# Altium schematic sheet [10]Giroscopio.SchDoc
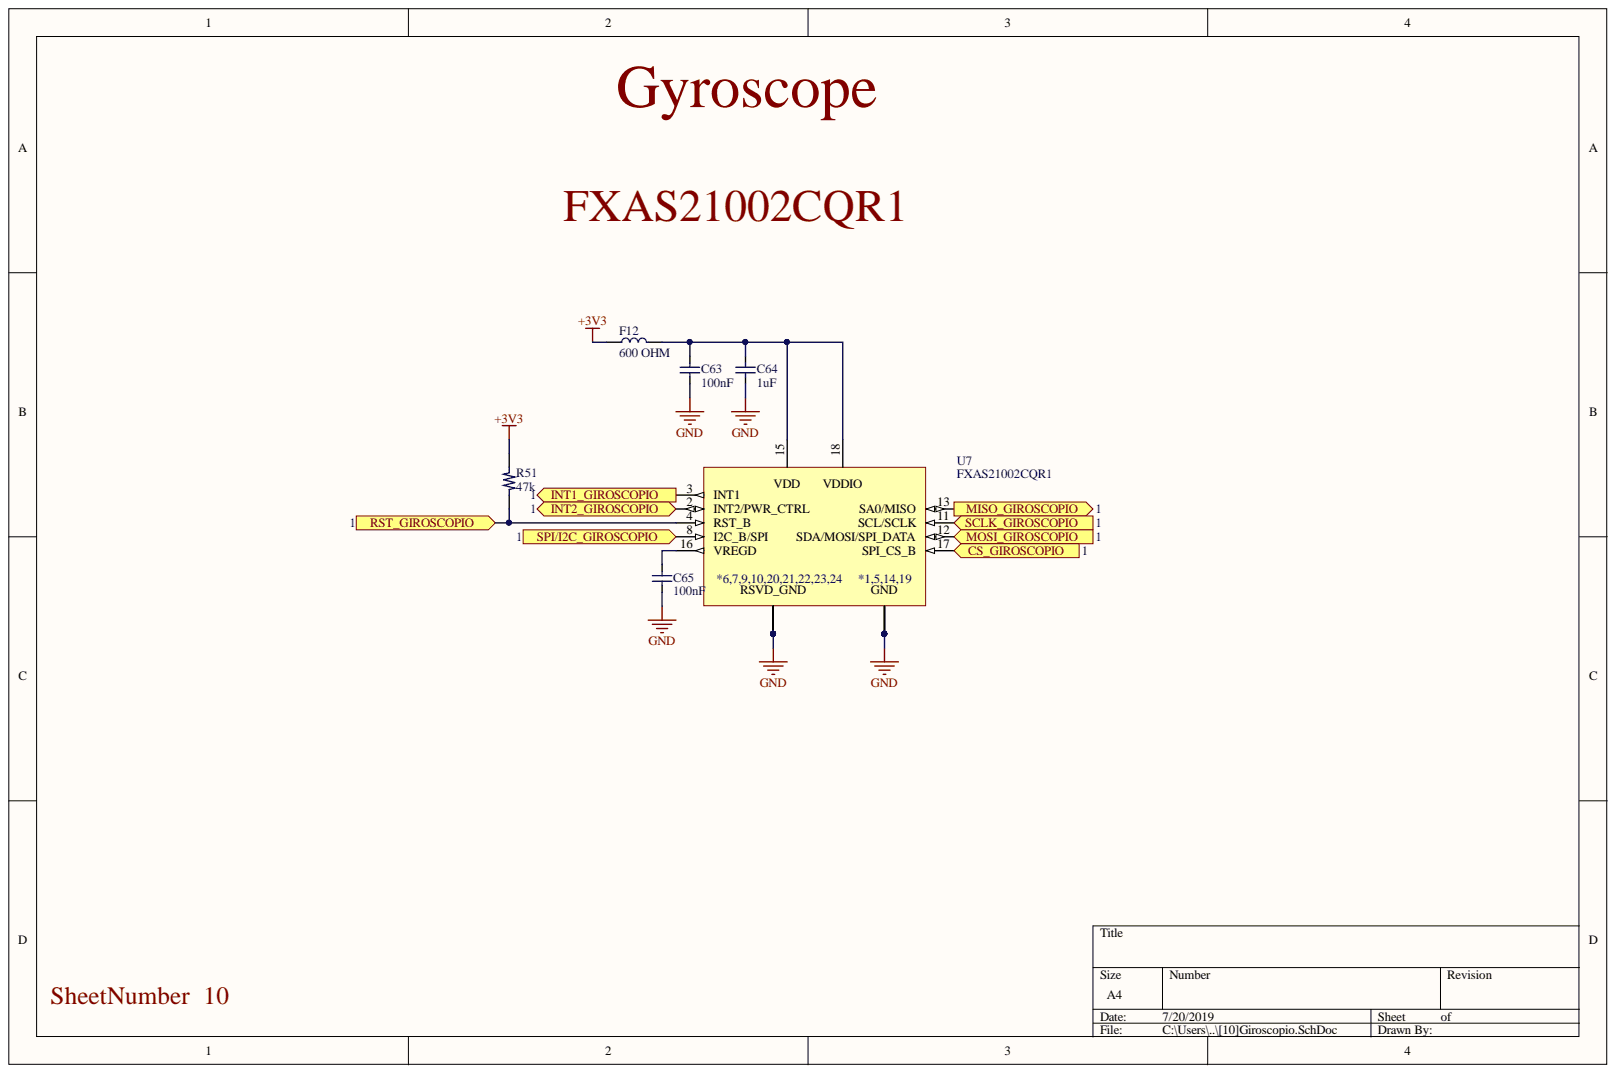
[diagram p0: [10]Giroscopio.SchDoc]

## Parts
C63  100nF  [C0805]
C64  1uF  [C0805]
C65  100nF  [C0805]
F12  600 OHM  [FB0805]
R51  47k  [R0805]
U7  FXAS21002CQR1  [Quad24]

## Ports
CS_GIROSCOPIO, INT1_GIROSCOPIO, INT2_GIROSCOPIO, MISO_GIROSCOPIO, MOSI_GIROSCOPIO, RST_GIROSCOPIO, SCLK_GIROSCOPIO, SPI/I2C_GIROSCOPIO

## Nets
+3V3: F12.1 R51.2
GND: C63.1 C64.1 C65.1 U7.1 U7.5 U7.6 U7.7 U7.9 U7.10 U7.14 U7.19 U7.20 U7.21 U7.22 U7.23 U7.24
CS_GIROSCOPIO: U7.17(SPI_CS_B) ; port CS_GIROSCOPIO
INT1_GIROSCOPIO: U7.3(INT1) ; port INT1_GIROSCOPIO
INT2_GIROSCOPIO: U7.2(INT2/PWR_CTRL) ; port INT2_GIROSCOPIO
MISO_GIROSCOPIO: U7.13(SA0/MISO) ; port MISO_GIROSCOPIO
MOSI_GIROSCOPIO: U7.12(SDA/MOSI/SPI_DATA) ; port MOSI_GIROSCOPIO
RST_GIROSCOPIO: R51.1 U7.4(RST_B) ; port RST_GIROSCOPIO
SCLK_GIROSCOPIO: U7.11(SCL/SCLK) ; port SCLK_GIROSCOPIO
SPI/I2C_GIROSCOPIO: U7.8(I2C_B/SPI) ; port SPI/I2C_GIROSCOPIO
NetC63_2: C63.2 C64.2 F12.2 U7.15 U7.18
NetC65_2: C65.2 U7.16(VREGD)

## Library data of the parts
C63 C65 = CC0805ZRY5V9BB104: CAP CER 0.1UF 50V Y5V 0805; Mfr 1: Yageo; Component Kind: Standard; Library Name: Components.SchLib; Mfr 1 PN: CC0805ZRY5V9BB104
C64 = UMK212BJ105KG-T: CAP CER 1UF 50V X5R 0805; Mfr 1: Taiyo Yuden; Component Kind: Standard; Library Name: Components.SchLib; Mfr 1 PN: UMK212BJ105KG-T
F12 = MH2029-601Y: FERRITE BEAD 600 OHM 0805 1LN; Mfr 1: Bourns Inc.; Component Kind: Standard; Library Name: Components.SchLib; Mfr 1 PN: MH2029-601Y
R51 = RC0805FR-0747KL: RES SMD 47K OHM 1% 1/8W 0805; Mfr 1: Yageo; Component Kind: Standard; Library Name: Components.SchLib; Mfr 1 PN: RC0805FR-0747KL
U7 = FXAS21002CQR1: GYROSCOPE 3-AXIS 24QFN; Mfr 1: NXP USA Inc.; Component Kind: Standard; Library Name: Components.SchLib; Mfr 1 PN: FXAS21002CQR1
